# Altium schematic sheet 12V Buck A.SchDoc
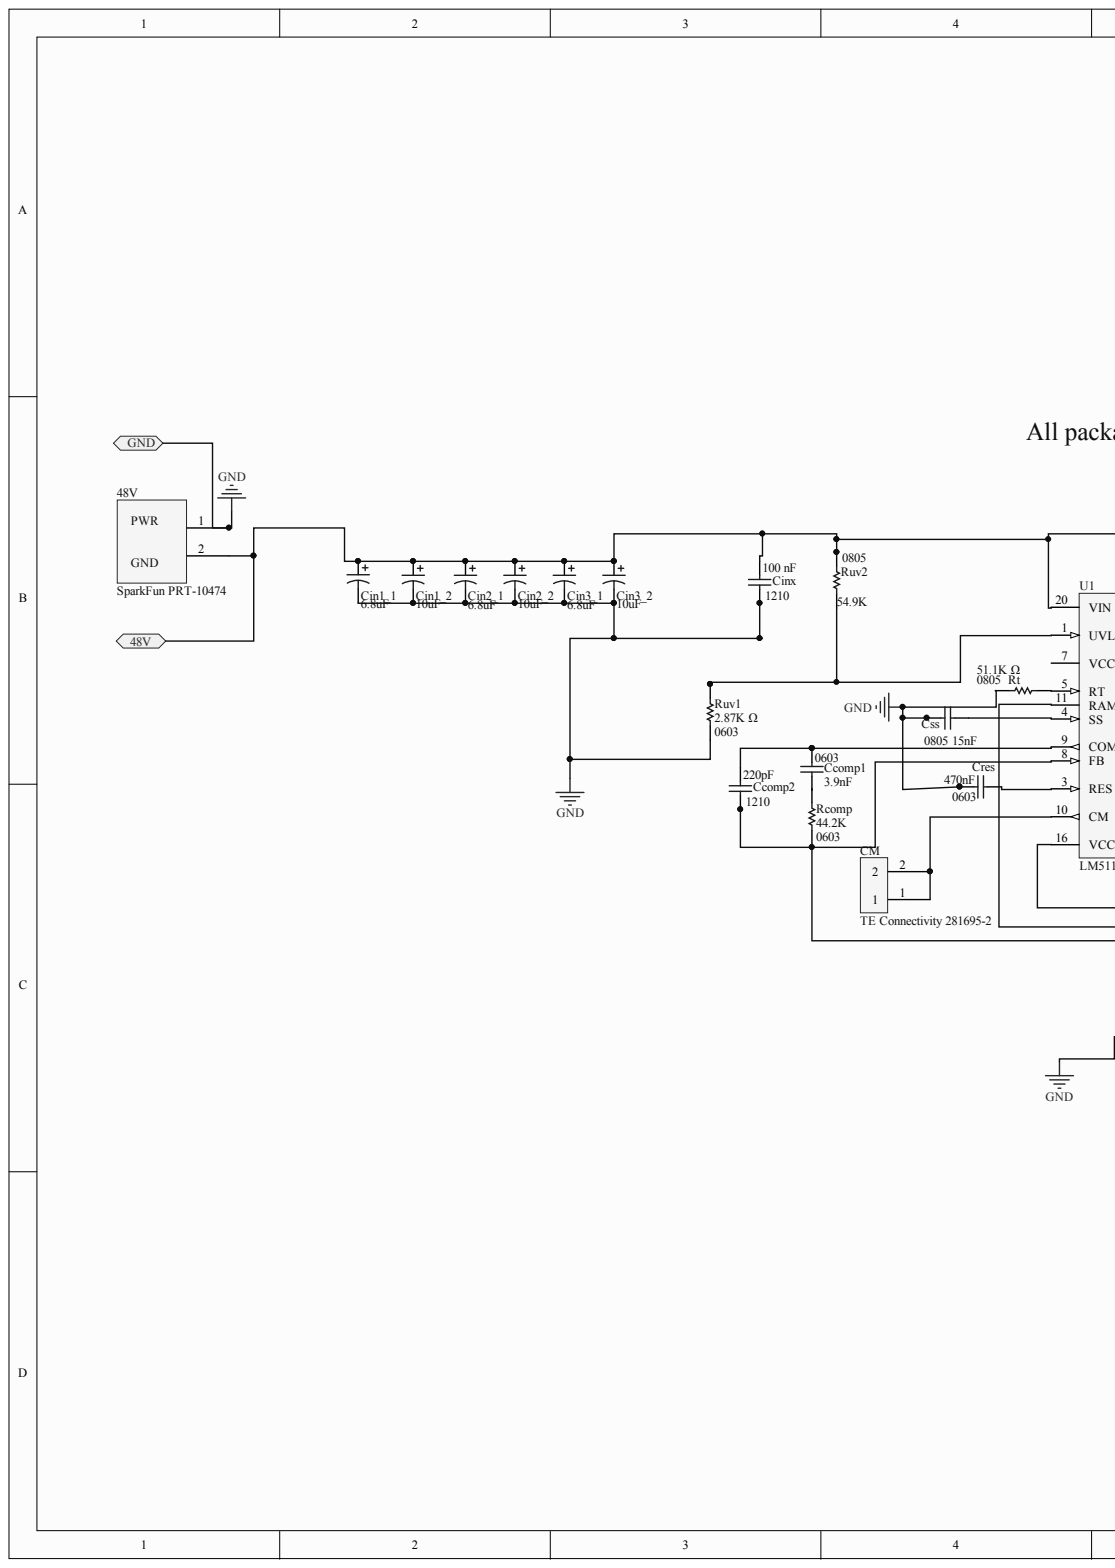
[diagram p0: 12V Buck A.SchDoc, left]
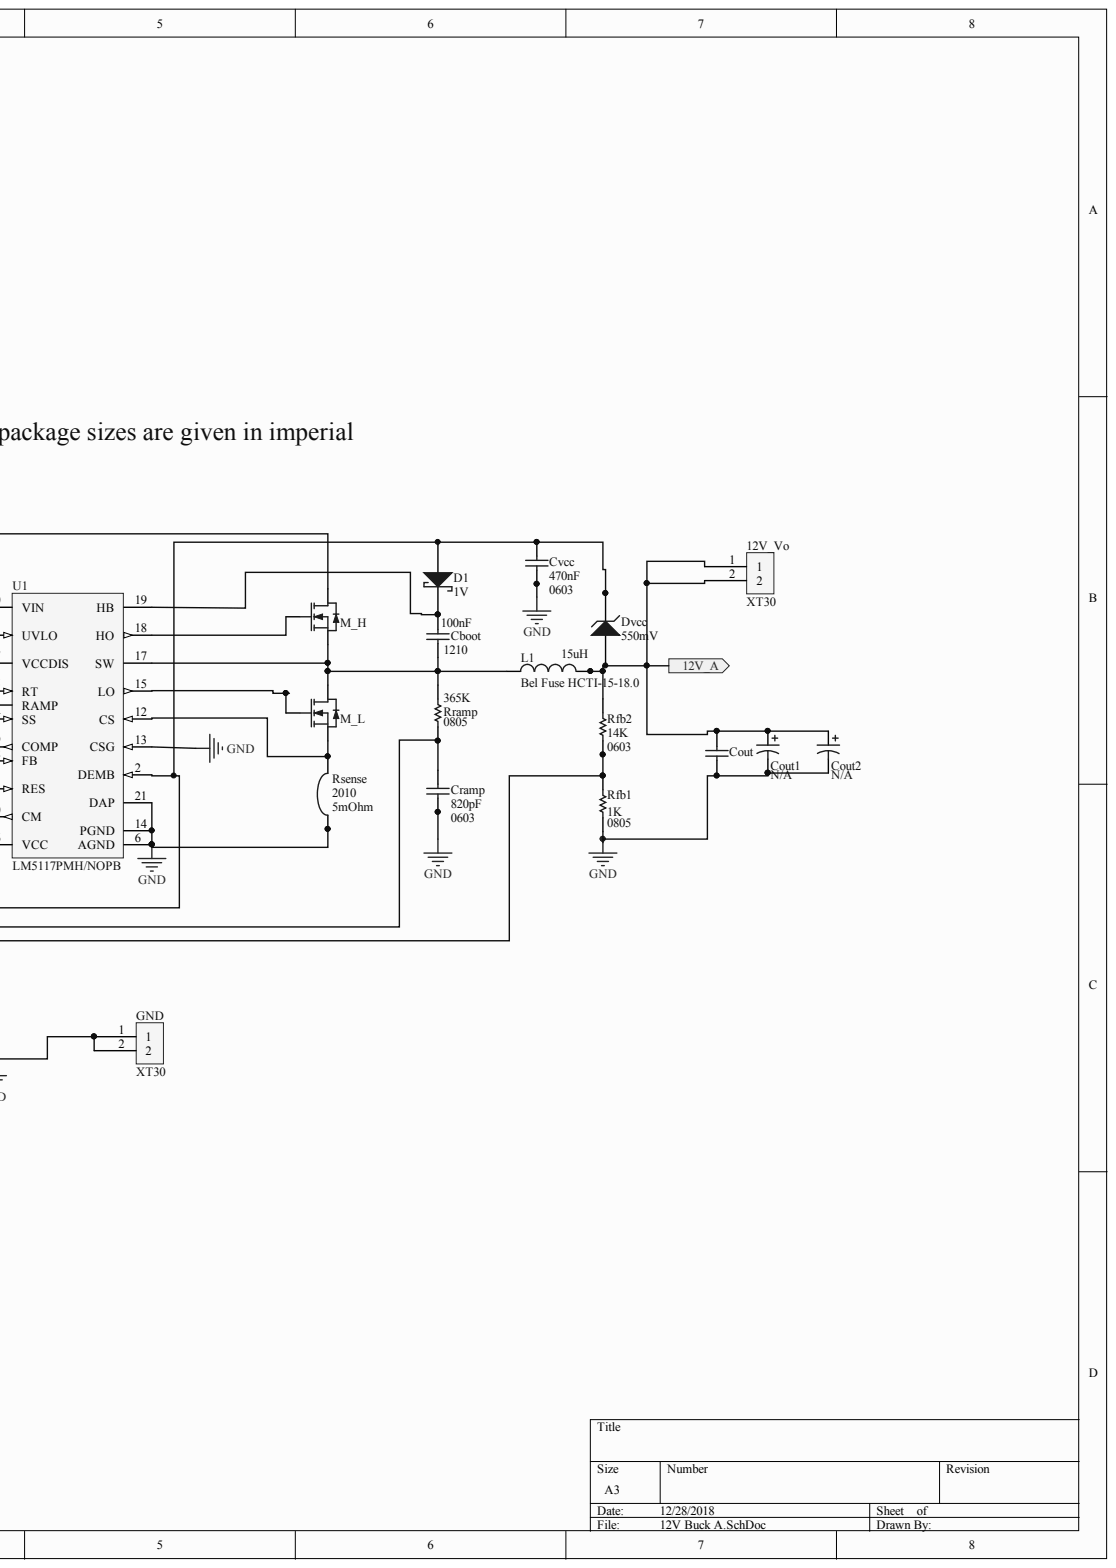
[diagram p1: 12V Buck A.SchDoc, right]

## Parts
12V_Vo  XT30  [PCB-8h6goupi0sbkduz6cbnu-1]
48V  SparkFun PRT-10474  [PCB-0yod6kgj5trn1afdndpj-1]
Cboot  Capacitance: 100nF  Package: 1210  [PCB-xq3c4pfyq04n43f4i2bn-1]  (hidden comment: Taiyo Yuden HMK325B7225KN-T)
Ccomp1  Capacitance: 3.9nF  Case/Package: 0603  [0603-CAP]  (hidden comment: Kemet C0603C561J5GACTU)
Ccomp2  Capacitance: 220pF  Package: 1210  [PCB-xq3c4pfyq04n43f4i2bn-1]  (hidden comment: Taiyo Yuden HMK325B7225KN-T)
Cin1_1  Capacitance: 6.8uF  [PCB-2jf9nlde2fxplectirwc-1]  (hidden comment: Cap Pol2)
Cin1_2  Capacitance: 10uF  [PCB-tfzooo8wqykrjp6gvckq-1]
Cin2_1  Capacitance: 6.8uF  [PCB-2jf9nlde2fxplectirwc-1]  (hidden comment: Cap Pol2)
Cin2_2  Capacitance: 10uF  [PCB-tfzooo8wqykrjp6gvckq-1]
Cin3_1  Capacitance: 6.8uF  [PCB-2jf9nlde2fxplectirwc-1]  (hidden comment: Cap Pol2)
Cin3_2  Capacitance: 10uF  [PCB-tfzooo8wqykrjp6gvckq-1]
Cinx  Capacitance: 100 nF  Package: 1210  [PCB-xq3c4pfyq04n43f4i2bn-1]  (hidden comment: Taiyo Yuden HMK325B7225KN-T)
CM  TE Connectivity 281695-2  Manufacturer Part Number: 281695-2  [PCB-55uug6ujkbv21exb3umc-1]
Cout  (no value)  [PCB-145573-1]
Cout1  Cap Pol2  [PCB-2jf9nlde2fxplectirwc-1]  (value not drawn)
Cout2  Cap Pol2  [PCB-2jf9nlde2fxplectirwc-1]  (value not drawn)
Cramp  Capacitance: 820pF  Case/Package: 0603  [0603-CAP]  (hidden comment: Kemet C0603C561J5GACTU)
Cres  Capacitance: 470nF  Case/Package: 0603  [0603-CAP]  (hidden comment: Kemet C0603C561J5GACTU)
Css  Capacitance: 15nF  Package: 0805  [CAPC2014X135X70LL20]  (hidden comment: AVX Interconnect / Elco TLCR107M004XTA)
Cvcc  Capacitance: 470nF  Case/Package: 0603  [0603-CAP]  (hidden comment: Kemet C0603C561J5GACTU)
D1  1V  [PCB-5bn641f9tldax80abqio-1]
Dvcc  550mV  [PCB-t9nfn559t63jn2ztb8uq-1]
GND  XT30  [PCB-8h6goupi0sbkduz6cbnu-1]
L1  Bel Fuse HCTI-15-18.0  Inductance: 15uH  Manufacturer Part Number: HCTI-15-18.0  [PCB-7hxld5628s5vzrllttov-1]
M_H  IRF540N  [IRF540N]  (value not drawn)
M_L  IRF540N  [IRF540N]  (value not drawn)
Rcomp  Package: 0603  Resistance: 44.2K  [RESC1609X55X30ML10T20]  (hidden comment: Vishay Dale TNPU0603100RBZEN00)
Rfb1  Case/Package: 0805  Resistance: 1K  [RESC2013X70X40ML20T20]
Rfb2  Package: 0603  Resistance: 14K  [RESC1609X55X30ML10T20]  (hidden comment: Vishay Dale TNPU0603100RBZEN00)
Rramp  Case/Package: 0805  Resistance: 365K  [RESC2013X70X40ML20T20]
Rsense  Case/Package: 2010  Resistance: 5mOhm  [RESC5025X07N]  (hidden comment: Vishay CRCW20100000Z0EF)
Rt  Case/Package: 0805  Resistance: 51.1K Ω  [RESC2013X70X40ML20T20]
Ruv1  Package: 0603  [RESC1609X55X30ML10T20]  (hidden comment: Vishay Dale TNPU0603100RBZEN00)
Ruv2  Case/Package: 0805  Resistance: 54.9K  [RESC2013X70X40ML20T20]
U1  LM5117PMH/NOPB  [MXA20A_N]

## Ports
12V_A, 48V, GND

## Nets
12V_A: 12V_Vo.1 12V_Vo.2 Cout.2 Cout1.1 Cout2.1 Dvcc.1 L1.2 Rfb2.2 ; port 12V_A
48V: 48V.2 Cin1_1.1 Cin1_2.1 Cin2_1.1 Cin2_2.1 Cin3_1.1 Cin3_2.1 Cinx.2 M_H.2 Ruv2.2 U1.20(VIN) ; port 48V
GND: 48V.1 Cin1_1.2 Cin1_2.2 Cin2_1.2 Cin2_2.2 Cin3_1.2 Cin3_2.2 Cinx.1 Cout.1 Cout1.2 Cout2.2 Cramp.1 Cres.1 Css.1 Cvcc.1 GND.1 GND.2 Rfb1.2 Rsense.1 Rt.2 Ruv1.1 U1.6(AGND) U1.13(CSG) U1.14(PGND) U1.21(DAP) ; port GND
NetCboot_1: Cboot.1 L1.1 M_H.3 M_L.2 Rramp.2 U1.17(SW)
NetCboot_2: Cboot.2 D1.2 U1.19(HB)
NetCcomp1_1: Ccomp1.1 Rcomp.2
NetCcomp1_2: Ccomp1.2 Ccomp2.2 U1.9(COMP)
NetCcomp2_1: Ccomp2.1 Rcomp.1 Rfb1.1 Rfb2.1 U1.8(FB)
NetCM_1: CM.1 CM.2 U1.10(CM)
NetCramp_2: Cramp.2 Rramp.1 U1.11(RAMP)
NetCres_2: Cres.2 U1.3(RES)
NetCss_2: Css.2 U1.4(SS)
NetCvcc_2: Cvcc.2 D1.1 Dvcc.2 U1.2(DEMB) U1.16(VCC)
NetM_H_1: M_H.1 U1.18(HO)
NetM_L_1: M_L.1 U1.15(LO)
NetM_L_3: M_L.3 Rsense.2 U1.12(CS)
NetRt_1: Rt.1 U1.5(RT)
NetRuv1_2: Ruv1.2 Ruv2.1 U1.1(UVLO)

Not connected: U1 7(VCCDIS)

## Library data of the parts
12V_Vo GND = CMP-nlx8yci0umf6n9sk0ty3-4: Very similar to the XT60 models, but smaller and made for 30A; Component Kind: Standard; Library Name: CommunityVault
48V = CMP-d8151592827e07d2-4: XT60 Connectors Male/female Pair; Component Kind: Standard; Library Name: CommunityVault
Cboot Ccomp2 Cinx = CMP-050ecc6fcd80dac9-2: Cap Ceramic 2.2uF 100V X7R 10% SMD 1210 125°C Embossed T/R; Voltage Rating (DC): 100.0 V; Capacitance Tolerance: ±10%; Component Kind: Standard; Dielectric Characteristic: X7R; Library Name: CommunityVault; Lifecycle Status: Not Listed by Manufacturer; Manufacturer Part Number: HMK325B7225KN-T; Number of Pins: 2; OctopartID: 050ecc6fcd80dac9; Octopart URL: http://octopart.com/hmk325b7225kn-t-taiyo+yuden-7073256; Packaging: Cut Tape (CT); RoHS: Compliant
Ccomp1 Cramp Cres Cvcc = CMP-123892-4: CAP CER 560PF 50V 5% NP0 0603; Dielectric Characteristic: C0G/NP0; Dielectric Material: Ceramic; Lead-Free Status: Lead Free; Voltage Rating (DC): 50.0 V; Capacitance Tolerance: ±5%; Component Kind: Standard; Library Name: CommunityVault; Manufacturer Part Number: C0603C561J5GACTU; Mounting Style: Surface Mount; Number of Pins: 2; OctopartID: 0aabc3a08ab6d56c; Octopart Url: https://octopart.com/c0603c561j5gactu-kemet-107899; Packaging: Cut Tape (CT); REACH SVHC Compliance: No SVHC; RoHS: Compliant; Size-Height: 0.0008 m; Size-Length: 0.0016 m; Size-Width: 0.0008 m
Cin1_1 Cin2_1 Cin3_1 Cout1 Cout2 = CMP-1b08d3184bfdc4cf-1: Cap Aluminum Lytic 100uF 16V 20% (6.3 X 11.2mm) Radial 2.5mm 290mA 1000h 105C Ammo Pack; Manufacturer Part Number: EEU-FC1C101H; Component Kind: Standard; Library Name: CommunityVault; Lifecycle Status: Not Listed by Manufacturer; Octopart URL: http://octopart.com/eeu-fc1c101h-panasonic-18511251
  Cout1 Cout2: Capacitance: N/A
Cin1_2 Cin2_2 Cin3_2 = CMP-dec21744279d0105-1: Cap Aluminum 220uF 63V 20% (12.5 X 20mm) Radial 5mm 1285mA 5000h 105Â°C Ammo Pack; Manufacturer Part Number: EEU-FC1J221SB; Lead-Free Status: Lead Free; Component Kind: Standard; Library Name: CommunityVault; Lifecycle Status: Not Listed by Manufacturer; OctopartID: dec21744279d0105; Octopart URL: http://octopart.com/eeu-fc1j221sb-panasonic-9231417; RoHS: Compliant
CM = CMP-e5782e1080433abf-3: AMPMODU HE14 Series, 2.54mm Pitch 2 Way 1 Row Straight PCB Header, Solder Term; Gender: Male; Lead-Free Status: Lead Free; Number of Positions: 2; Component Kind: Standard; Contact Plating: Tin, Tin over Nickel; Housing Color: Blue; Housing Material: Thermoplastic; Library Name: CommunityVault; Mounting Style: Through Hole; OctopartID: e5782e1080433abf; Octopart URL: https://octopart.com/281695-2-te+connectivity-39993437; Operating Temperature: -55.0 °C to 105 °C; Orientation: Vertical; Packaging: Bulk; REACH SVHC Compliance: No SVHC; RoHS: Compliant
Cout = CMP-145573-2: VISHAY BC COMPONENTS   D102K20Y5PH63L2R   CAP, CER, Y5P, 1NF, 100V, RAD; Capacitance: 1e-09 F; Capacitance Tolerance: ±10%; Lead Length: 0.03 m; Voltage Rating (DC): 100.0 V; Component Kind: Standard; Dielectric Characteristic: Y5P; Library Name: CommunityVault; Manufacturer Part Number: D102K20Y5PH63L2R; Mounting Style: Through Hole; Number of Pins: 2; OctopartID: 8cd444c869d25313; Octopart Url: https://octopart.com/d102k20y5ph63l2r-vishay+bccomponents-18268256; REACH SVHC Compliance: No SVHC; RoHS: Compliant
Css = CMP-224528-2: CAP TANT 100UF 4V 20% 0805; Case/Package (SI): 2012; Dielectric Material: Tantalum; Equivalent Series Resistance (ESR): 5.0 Ω; Lead-Free Status: Lead Free; Voltage Rating (DC): 4.0 V; Capacitance Tolerance: ±20%; Component Kind: Standard; Library Name: CommunityVault; Manufacturer Part Number: TLCR107M004XTA; Mounting Style: Surface Mount; Number of Pins: 2; OctopartID: 24c5edcc5c94fb02; Octopart Url: https://octopart.com/tlcr107m004xta-avx+interconnect+%2F+elco-220471; REACH SVHC Compliance: No SVHC; RoHS: Compliant; Size-Height: 0.00135 m; Size-Length: 0.0025 m; Size-Width: 0.00135 m
D1 = CMP-c07c0973e9121fbb-4: Semiconductors and Actives, Discretes (diodes, transistors, thyristors ...), Diodes; Manufacturer Part Number: MBR0520L; Lead-Free Status: Lead Free; Component Kind: Standard; Library Name: CommunityVault
Dvcc = CMP-921b59b4313a4ddc-1: DZ2S036M0L Series 150 mW 3.6 V 200 mA Surface Mount Zener Diode - SC-79; Component Kind: Standard; Library Name: CommunityVault; Lifecycle Status: Not Listed by Manufacturer; Octopart URL: http://octopart.com/dz2s036m0l-panasonic-44402247; REACH SVHC Compliance: No SVHC; RoHS: Compliant
L1 = CMP-4bae8655b2279469-1: Inductor Power Shielded Toroid 15uH/9.5uH 15% 1KHz 18A 6mOhm DCR RDL; Component Kind: Standard; Library Name: CommunityVault; OctopartID: 4bae8655b2279469; Octopart URL: https://octopart.com/hcti-15-18.0-bel+fuse-82959599; RoHS: Compliant
M_H M_L = CMP-9181541-15: Trans MOSFET N-CH 100V 33A 3-Pin(3+Tab) TO-220AB; Continuous Drain Current (Ids): 33.0 A; Current Rating: 27.0 A; Drain to Source Voltage (Vds): 100.0 V; Lead-Free Status: Contains Lead; Component Kind: Standard; Library Name: CommunityVault; Lifecycle Status: Active; Manufacturer Part Number: IRF540N; Mounting Style: Through Hole; OctopartID: 66c3afc94f2db904; Octopart Url: https://octopart.com/irf540n-international+rectifier-3208; Packaging: Tube; Part Family: IRF540N; RoHS: Non-Compliant; Simulation: irf540; Voltage Rating (DC): 100.0 V
Rcomp Rfb2 = CMP-1870598-2: Thin Film Resistors - SMD 1/10watt 100ohms 0.1% 5ppm; Power Rating: 0.1 W; Voltage Rating (DC): 75.0 V; Component Kind: Standard; Library Name: CommunityVault; Manufacturer Part Number: TNPU0603100RBZEN00; OctopartID: 67d2ac7ddd43b815; Octopart Url: https://octopart.com/tnpu0603100rbzen00-vishay+dale-44408823; Packaging: Cut Tape (CT); Resistance Tolerance: ±0.1%; RoHS: Exempt
Rfb1 Rramp Rt Ruv2 = CMP-3687771-2: Thick Film Resistors - SMD 0805 169Kohms 1% Tol; Manufacturer Part Number: ERJ-6ENF1693V; Case/Package (SI): 2012; Lead-Free Status: Lead Free; Power Rating: 0.125 W; Voltage Rating (DC): 150.0 V; Component Kind: Standard; Library Name: CommunityVault; Lifecycle Status: Not Listed by Manufacturer; Mounting Style: Surface Mount; OctopartID: e308294f6b803ccf; Octopart Url: https://octopart.com/erj-6enf1693v-panasonic-55422446; REACH SVHC Compliance: No SVHC; Resistance Tolerance: ±1%; RoHS: Compliant; Size-Height: 0.0006 m; Size-Length: 0.002 m; Size-Width: 0.00125 m
Rsense = CMP-81594a09aa4e5e9e-2: Resistor; Thick Film; 0 Ohms; 0.75 W; 0 Ohms Jumper; SMT; 2010; TCR 0 ppm/DegC; TR; Power Rating: 750 mW; Resistance Tolerance: ±5%; Voltage Rating (DC): 400 V; Component Kind: Standard; Library Name: CommunityVault; Number of Pins: 2; Packaging: Tape & Reel (TR), Cut Tape (CT); Size-Width: 2.50 mm
Ruv1 = CMP-1870598-2: Thin Film Resistors - SMD 1/10watt 100ohms 0.1% 5ppm; Power Rating: 0.1 W; Resistance: 2.87K Ω; Voltage Rating (DC): 75.0 V; Component Kind: Standard; Library Name: CommunityVault; Manufacturer Part Number: TNPU0603100RBZEN00; OctopartID: 67d2ac7ddd43b815; Octopart Url: https://octopart.com/tnpu0603100rbzen00-vishay+dale-44408823; Packaging: Cut Tape (CT); Resistance Tolerance: ±0.1%; RoHS: Exempt
U1 = CMP-0069-02227-2: Wide Input Range Synchronous Buck Controller with Analog Current Monitor, 20-pin TSSOP-EP, 73 Rail; Frequency: 750000.0 Hz; Lead-Free Status: Lead Free; Number of Outputs: 1; Output Current: 20.0 A; Output Voltage: 0.8 V; Quiescent Current: 0.0048 A; Component Kind: Standard; Library Name: CommunityVault; Lifecycle Status: Active; Manufacturer Part Number: LM5117PMH/NOPB; Number of Pins: 20; Number of Regulated Outputs: 1; OctopartID: 371aff6ab755e8dd; Octopart Url: https://octopart.com/lm5117pmh%2Fnopb-texas+instruments-24814889; REACH SVHC Compliance: No SVHC; RoHS: Compliant; Topology: Buck
